# Altium schematic sheet Power_supply.SchDoc
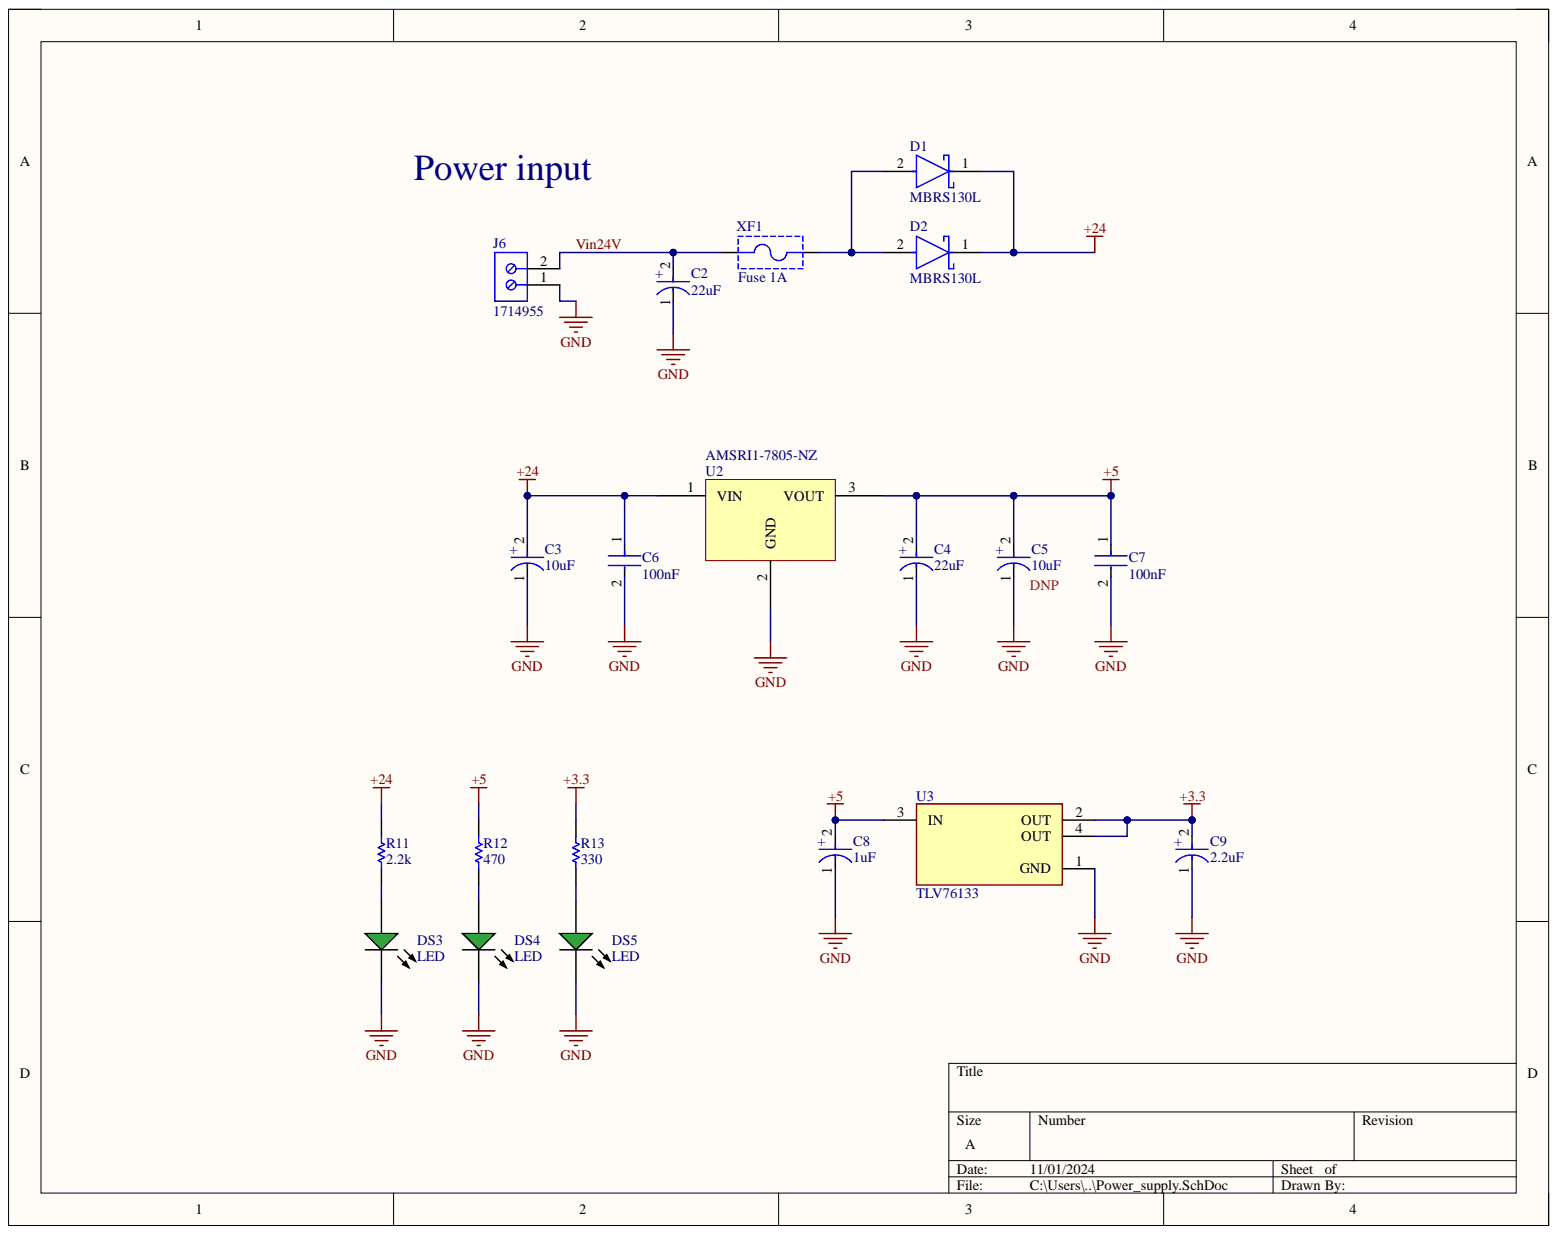
[diagram p0: Power_supply.SchDoc]

## Parts
C2  22uF  [FP-FCE-MFG]
C3  10uF  [FP-FKD-MFG]
C4  22uF  [FP-FCE-MFG]
C5  10uF  [FP-FKD-MFG]
C6  100nF  [FP-0805-L_2_01_0_2-W_1_25-IPC_B]
C7  100nF  [FP-0805-L_2_01_0_2-W_1_25-IPC_B]
C8  1uF  [FP-FKD-MFG]
C9  2.2uF  [FP-FKD-MFG]
D1  MBRS130L  [FP-403AF-MFG]
D2  MBRS130L  [FP-403AF-MFG]
DS3  LED  [AVAG-HSMX-C170_V]
DS4  LED  [AVAG-HSMX-C170_V]
DS5  LED  [AVAG-HSMX-C170_V]
J6  1714955  [PHOE-1714955_V]
R11  2.2k  [RESC2013X50X30LL20T20]
R12  470  [RESC2013X50X30LL20T20]
R13  330  [RESC2013X50X30LL20T20]
U2  AMSRI1-7805-NZ  [SIP750W46P254L1400H1010Q3]
U3  TLV76133  [FP-DCY0004A-MFG]
XF1  Fuse 1A  [696106003002]

## Nets
+3.3: C9.2 R13.2 U3.2(OUT) U3.4(OUT)
+5: C4.2 C5.2 C7.1 C8.2 R12.2 U2.3 U3.3(IN)
+24: C3.2 C6.1 D1.1 D2.1 R11.2 U2.1
GND: C2.1 C3.1 C4.1 C5.1 C6.2 C7.2 C8.1 C9.1 DS3.2 DS4.2 DS5.2 J6.1 U2.2 U3.1(GND)
Vin24V: C2.2 J6.2 XF1.1
NetD1_2: D1.2 D2.2 XF1.2
NetDS3_1: DS3.1 R11.1
NetDS4_1: DS4.1 R12.1
NetDS5_1: DS5.1 R13.1

## Library data of the parts
C2 C4 = CMP-05427-002128-1: CAP ALUM 22UF 20% 50V SMD; Dielectric Material: Aluminium; ESR (Equivalent Series Resistance): 700mR; Lead Length: 3.4mm; Leakage Current: 3uA; Life (Hours): 1000Hour; Polarity: Polar; Ripple Current: 120mA; Capacitance: 22uF; Case/Package: Radial; Component Kind: Standard; Construction: Cylindrical; Contact Plating: Tin; Diameter: 8mm; Height: 6.2mm; Height - Seated (Max): 0.244inch; Impedance: 700mR; Lead Free: Lead Free; Lead Pitch: 2.2mm; Length: 6.5mm; Library Name: Altium Content Vault; Material: Aluminium; Max Operating Temperature: 105°C; Min Operating Temperature: -40°C; Mount: Surface Mount; Number of Pins: 2; Package Quantity: 1000; Packaging: Tape and Reel; Radiation Hardening: No; Reach SVHC Compliant: Yes; Ripple Current (AC): 120mA; RoHS Compliant: Yes; Series: FC; Termination: SMD/SMT; Tolerance: 20%; Voltage: 50V; Voltage Rating: 50V; Voltage Rating (DC): 50V; Width: 8.3mm
C3 C5 C8 C9 = CMP-2000-06207-2: CAP ALUM 10UF 20% 50V SMD; ESR (Equivalent Series Resistance): 880mR; Lead Diameter: 0.65mm; Leakage Current: 3uA; Life (Hours): 2000Hour; Polarity: Polar; Ripple Current: 165mA; Capacitance: 10uF; Case/Package: Radial; Component Kind: Standard; Construction: Cylindrical; Contact Plating: Tin; Diameter: 6.3mm; Height: 5.8mm; Height - Seated (Max): 0.24inch; Impedance: 880mR; Lead Free: Lead Free; Lead Pitch: 1.8mm; Length: 5.8mm; Library Name: Altium Content Vault; Max Operating Temperature: 105°C; Min Operating Temperature: -55°C; Mount: Surface Mount; Number of Pins: 2; Package Quantity: 1000; Packaging: Tape and Reel; Radiation Hardening: No; REACH SVHC: No SVHC; Ripple Current (AC): 165mA; RoHS Compliant: Yes; Series: FK; Size: 5.8mm; Termination: SMD/SMT; Tolerance: 20%; Voltage: 50V; Voltage Rating: 50V; Voltage Rating (DC): 50V; Width: 7.8mm
C6 C7 = CMP-2007-04174-2: General Purpose Ceramic Capacitor, 0805, 10nF, 10%, X7R, 15%, 100V; Capacitance: 100nF; Case Code (Imperial): 0805; Case Code (Metric): 2012; Case/Package: 0805; Component Kind: Standard; Construction: Flat; Contact Plating: Tin; Depth: 1.25mm; Dielectric: X7R; Dielectric Material: Ceramic; Height: 1.4mm; Lead Free: Lead Free; Length: 2.01mm; Library Name: Altium Content Vault; Max Operating Temperature: 125°C; Min Operating Temperature: -55°C; Mount: Surface Mount; Number of Pins: 2; Package Quantity: 2000; Packaging: Tape and Reel; Radiation Hardening: No; REACH SVHC: No SVHC; RoHS Compliant: Yes; Series: -; Termination: SMD/SMT; Thickness: 0.055inch; Tolerance: 10%; Voltage: 100V; Voltage Rating: 100V; Voltage Rating (DC): 100V; Width: 0.049inch
D1 D2 = CMP-00278-20916186-1: MBRS130L Rectifier Diode, Schottky, 1 Phase, 1 Element, 2A, 30V V(RRM), Silicon, DO-214AA; Manufacturer Lifecycle Status: OBSOLETE; Current Rating: 1A; Element Configuration: Single; Forward Voltage: 445mV; Max Forward Surge Current (Ifsm): 40A; Max Junction Temperature (Tj): 125°C; Max Reverse Leakage Current: 1mA; Polarity: Standard; Average Rectified Current: 2A; Case/Package: SMB; Component Kind: Standard; Forward Current: 2A; Height: 2.45mm; Lead Free: Lead Free; Length: 4.75mm; Library Name: Altium Content Vault; Lifecycle Status: Obsolete; Max Operating Temperature: 125°C; Max Repetitive Reverse Voltage (Vrrm): 30V; Max Reverse Voltage (DC): 30V; Max Surge Current: 40A; Min Operating Temperature: -65°C; Mount: Surface Mount; NexarPartId: 20916186; Number of Pins: 2; Output Current: 1A; Packaging: Cut Tape; Peak Non-Repetitive Surge Current: 40A; Peak Reverse Current: 1mA; Radiation Hardening: No; REACH SVHC: No SVHC; RoHS: Compliant; Schedule B: 8541100080; Voltage Rating (DC): 30V; Weight: 180mg; Width: 3.95mm
DS3-DS5 = CMP-2000-05062-1: Chip LED, Green, 2.2 V, -40 to 85 degC, 2-Pin SMD, Pb-Free, Tape and Reel; Manufacturer: Avago; Bulb Size: 1.25mmx1.4mm; Dominant Wavelength: 572nm; External Depth: 0.8mm; External Length / Height: 2mm; External Width: 1.25mm; Forward Current If: 20mA; Forward Voltage: 2.2V; Forward Voltage VF Max: 2.6V; LED Type: Standard; Lens Shape: Rectangular; Light Area Height: 1mm; Luminous Intensity: 15mcd; Luminous Intensity Min: 4mcd; No. of Pins: 2; Opto Mounting Orientation: Top; Peak Wavelength: 570nm; PIV Max: 5V; SVHC: NoSVHC(16-Jun-2014); Viewing Angle: 170deg; Component Kind: Standard; Datasheet URL: https://datasheet.ciiva.com/29280/180495-da-01-en-chip-led-hsmg-c170-gelb-gruen-29280971.pdf; Datasheet Version: Oct-08; Dominant Wavelength Typ: 572nm; Forward Current If Max: 20mA; LED Colour: Green; LED Mounting: SMD; Library Name: Altium Content Vault; Luminous Intensity Typ: 15mcd; Manufacturer URL: http://www.avagotech.com/; Mounting Type: SMD; MSL: MSL2A-4weeks; Operating Temperature Max: 85degC; Operating Temperature Min: -30degC; Operating Temperature Range: -30degCto+85degC; Opto Case Style: SMD; Package Description: 2-Pin Surface Mount Device, Body 2 x 1.25 mm, Height 0.8 mm; Package Reference: HSMX-C170; Packaging: CutTape; Peak Forward Current: 20mA; Storage Temperature Max: 85degC; Storage Temperature Min: -40degC; Voltage Vf Typ: 2.2V; Wavelength Typ: 570nm
J6 = CMP-2000-05797-1: PC Terminal Block, Pitch 6.35 mm, 1 x 2 Position, Height 21.5 mm, Tail Lenght 5.1 mm, RoHS, Bulk; Manufacturer: Phoenix Contact; Component Kind: Standard; Datasheet URL: http://media.digikey.com/pdf/Data%20Sheets/Phoenix%20Contact%20PDFs/1714955.pdf; Datasheet Version: 02/2010; Library Name: Altium Content Vault; Manufacturer URL: http://www.phoenixcontact.com/; Mounting Technology: ThroughHole; Package Description: PC Terminal Block, Pitch 6.35 mm, 1 x 2 Position, Height 21.5 mm, Tail Lenght 5.1 mm; Package Reference: 1714955
R11-R13 = CMP-2001-04896-1: Manufacturer: Vishay Dale; Part Number: CRCW08050000Z0EA; Component Kind: Standard; Library Name: Altium Content Vault
U2 = AMSRI1-7805-NZ: AMSRI1-7805-NZ, 5V / 1A DC output converter; Component Kind: Standard; Library Name: Schematic_library.SchLib
U3 = CMP-00370-134324849-1: TLV76133 1-A 16-V high-PSRR linear voltage regulator 4-SOT-223 -40 to 125; Manufacturer Lifecycle Status: ACTIVE; Accuracy: 1%; Dropout Voltage: 900mV; Max Junction Temperature (Tj): 125°C; Max Supply Voltage: 16V; Min Supply Voltage: 6.5V; Nominal Supply Current: 65µA; Number of Channels: 1; Output Current: 1A; Output Voltage: 5V; Case/Package: SOT-223; Component Kind: Standard; Height: 1.8mm; Length: 6.5mm; Library Name: Altium Content Vault; Lifecycle Status: Production; Max Operating Temperature: 125°C; Min Operating Temperature: -40°C; NexarPartId: 134324849; Number of Outputs: 1; Number of Pins: 4; Thickness: 1.6mm; Width: 3.5mm
XF1 = CMP-1502-00235-2: NexarPartId: 56808805; Fuse Current: 10A; Voltage Rating (AC): 250V; Component Kind: Standard; Height: 11.6mm; Library Name: Altium Content Vault; Max Operating Temperature: 120°C; Min Operating Temperature: -30°C; Mount: Through Hole; Packaging: Box; REACH SVHC: Unknown; RoHS: Non-Compliant
